# Altium schematic sheet Connectors.SchDoc(Connectors)
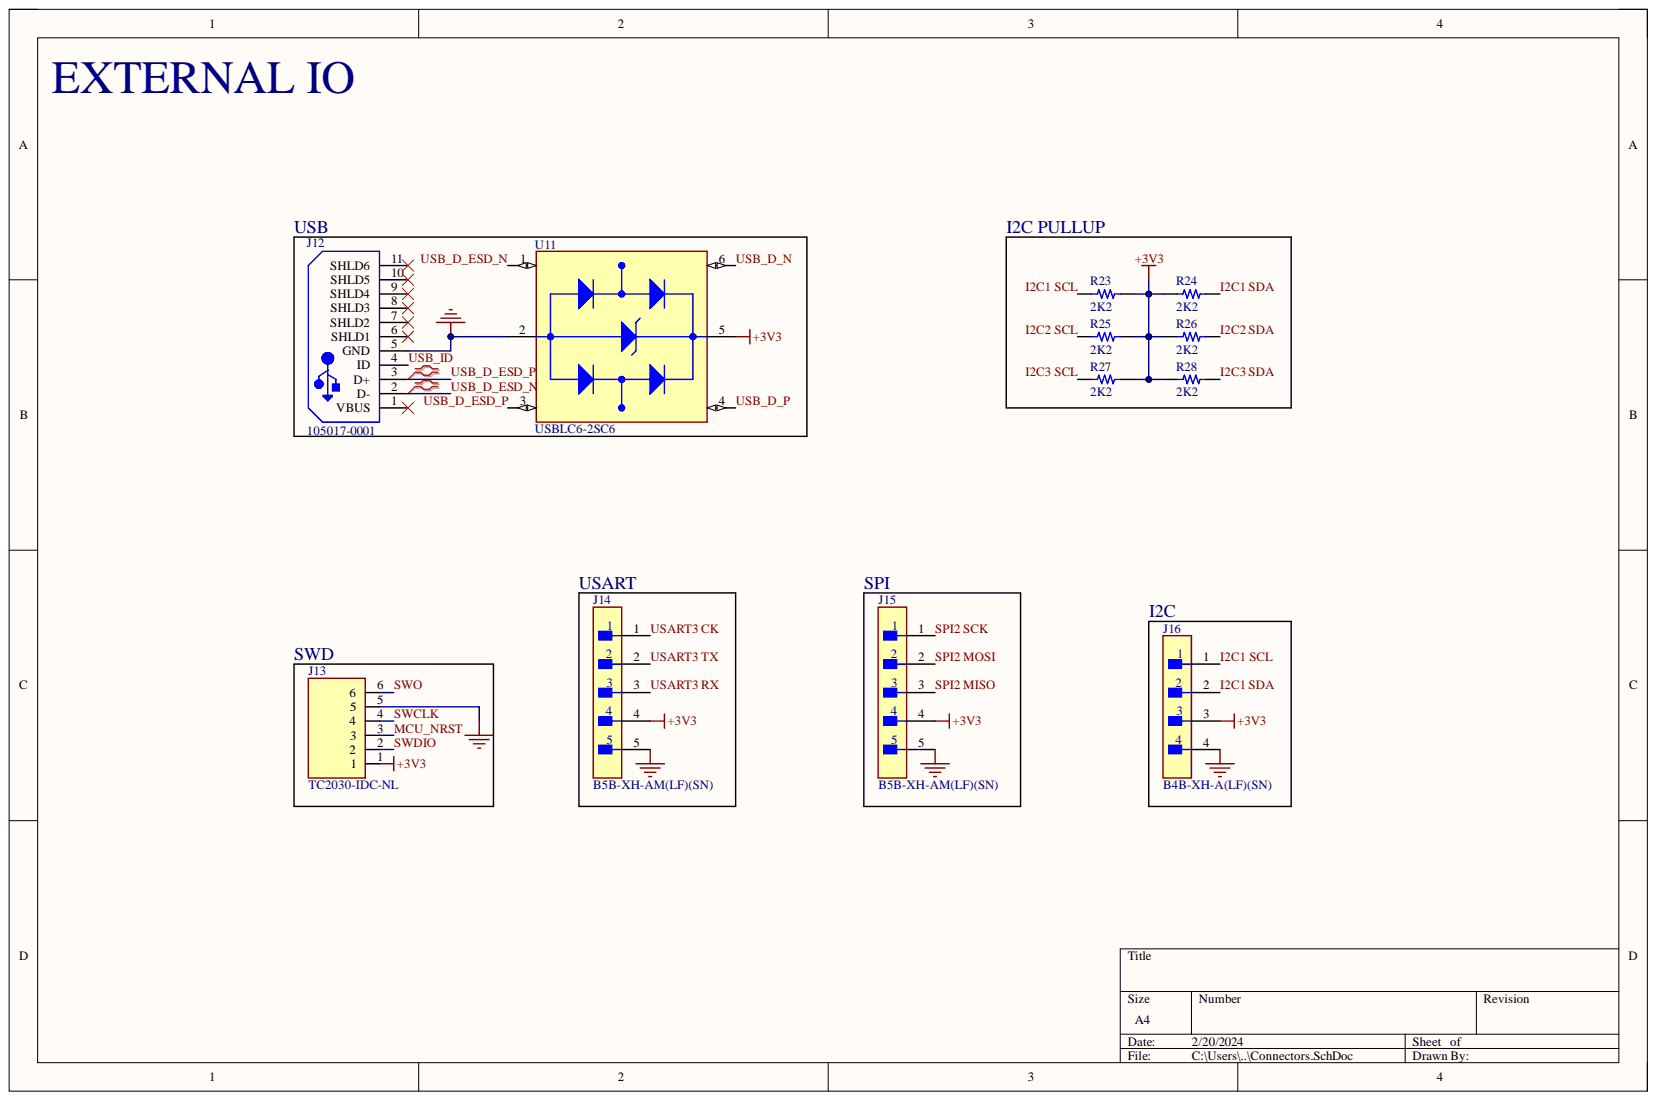
[diagram p0: Connectors.SchDoc]

## Parts
J12  105017-0001  [USB-MICRO-B_V]
J13  TC2030-IDC-NL  [TAG_TC2030-IDC-NL]
J14  B5B-XH-AM(LF)(SN)  [FP-B5B-XH-AM_LF_SN-MFG]
J15  B5B-XH-AM(LF)(SN)  [FP-B5B-XH-AM_LF_SN-MFG]
J16  B4B-XH-A(LF)(SN)  [FP-B4B-XH-A_LF_SN-MFG]
R23  2K2  [RES 0402_1005]
R24  2K2  [RES 0402_1005]
R25  2K2  [RES 0402_1005]
R26  2K2  [RES 0402_1005]
R27  2K2  [RES 0402_1005]
R28  2K2  [RES 0402_1005]
U11  USBLC6-2SC6  [SOT95P280X145-6N]

## Nets
+3V3: J13.1 J14.4 J15.4 J16.3 R23.1 R24.2 R25.1 R26.2 R27.1 R28.2 U11.5
GND: J12.5(GND) J13.5 J14.5 J15.5 J16.4 U11.2
I2C1 SCL: J16.1 R23.2
I2C1 SDA: J16.2 R24.1
I2C2 SCL: R25.2
I2C2 SDA: R26.1
I2C3 SCL: R27.2
I2C3 SDA: R28.1
MCU_NRST: J13.3
SPI2 MISO: J15.3
SPI2 MOSI: J15.2
SPI2 SCK: J15.1
SWCLK: J13.4
SWDIO: J13.2
SWO: J13.6
USART3 CK: J14.1
USART3 RX: J14.3
USART3 TX: J14.2
USB_D_ESD_N: J12.2(D-) U11.1
USB_D_ESD_P: J12.3(D+) U11.3
USB_D_N: U11.6
USB_D_P: U11.4
USB_ID: J12.4(ID)

Not connected: J12 1(VBUS) 6(SHLD1) 7(SHLD2) 8(SHLD3) 9(SHLD4) 10(SHLD5) 11(SHLD6)

## Library data of the parts
J12 = CMP-2000-05827-1: Micro-USB B Receptacle, Right Angle, Bottom Mount, Surface Mount, with Solder Tabs, -30 to 85 degC, 5-Pin USB, RoHS, Tape and Reel; Manufacturer: Molex; 3D Model: 105017-0001.stp; Catalog Drawings: 1050170001; Circuits: 5; Connector Type: USB-microB; Featured Product: Micro-USBConnectorsUSBConnectors; Mating Products: WM17145-ND-CABLEMICROUSBBTOSTDA1.0MWM17147-ND-CABLEMICROUSBBTOSTDA2.0MWM17146-ND-CABLEMICROUSBBTOSTDA1.5M; Online Catalog: Molex-MicroUSB; Other Names: WM1399DKR; Package Version: Rev. F, 12/2012; PCN Assembly/Origin: ResinSupplier21/Oct/2015; Voltage - Max: 30V; Category: Connectors,Interconnects; Component Kind: Standard; Datasheet URL: http://www.molex.com/elqNow/elqRedir.htm?ref=http://www.molex.com/webdocs/datasheets/pdf/en-us/1050170001_IO_CONNECTORS.pdf; Datasheet Version: 03/2016; Family: USB,DVI,HDMIConnectors; Features: Shielded,SolderRetention; Gender: Receptacle; Ingress Protection: -; Library Name: Altium Content Vault; Manufacturer URL: http://www.molex.com/; Mounting Technology: SurfaceMount; Mounting Type: BoardCutout,SurfaceMount,RightAngle; Number of Contacts: 5; Package Description: 5-Leads USB, Micro B, Body 7.5 x 5 mm, Pitch 0.65 mm; Package Reference: MICRO-B; Package URL: http://www.molex.com/pdm_docs/sd/1050170001_sd.pdf; Packaging: Digi-Reel®; RoHS Information: 1050170001CertofCompliance; Series: -; Specifications: -; Standard Package: 1
J13 = TC2030-IDC-NL: CABLE ADAPTER 6 POS; Availability: Unavailable; Component Kind: Standard; Library Name: TC2030-IDC-NL.IntLib; MP: TC2030-IDC-NL; Package: None; Price: None
J14 J15 = CMP-17439-000304-1: CONN HEADER VERT 5POS 2.5MM; Contact Resistance: 20mR; Mated Stacking Heights: 9.8mm; Max Current Rating: 3A; Max Voltage Rating (AC): 250V; Number of Positions: 5; Color: Green; Component Kind: Standard; Connector Type: Header; Contact Finish - Mating: TIN; Contact Material: Brass; Contact Plating: Copper; Contact Shape: Square; Contact Type: Male Pin; Depth: 5.75mm; Fastening Type: Detent; Flammability Rating: UL94 V-0; Housing Color: White; Housing Material: Polyamide; Insulation Color: Natural; Insulation Material: Nylon; Lead Free: Lead Free; Length: 14.9mm; Library Name: Altium Content Vault; Max Operating Temperature: 85°C; Min Operating Temperature: -25°C; Mount: Through Hole; Number of Rows: 1; Orientation: Straight; Packaging: Bulk; Pitch: 2.5mm; Series: XH; Termination: Solder; Voltage Rating: 250V
J16 = CMP-17439-000250-1: CONN HEADER VERT 4POS 2.5MM; Contact Resistance: 20mR; Current Rating: 3A; Gender: Male; Insulation Resistance: 1GR; Mated Stacking Heights: 9.8mm; Max Voltage Rating (AC): 250V; Number of Contacts: 4; Wire/Cable Gauge: 22AWG; Color: Natural; Component Kind: Standard; Connector Type: Header; Contact Finish - Mating: TIN; Contact Material: Brass; Contact Plating: Tin; Contact Shape: Square; Contact Type: Male Pin; Depth: 5.75mm; Fastening Type: Detent; Flammability Rating: UL94 V-0; Housing Color: White; Housing Material: Polyamide; Insulation Color: Natural; Insulation Material: Nylon; Lead Free: Lead Free; Lead Pitch: 2.5mm; Length: 12.4mm; Library Name: Altium Content Vault; Material: Polyamide; Max Current Rating: 3A; Max Operating Temperature: 85°C; Max Voltage Rating (DC): 250V; Min Operating Temperature: -25°C; Mount: Through Hole; Number of Positions: 4; Number of Rows: 1; Orientation: Straight; Package Quantity: 500; Packaging: Bulk; Pitch: 2.5mm; REACH SVHC: No SVHC; Series: XH; Termination: Solder; Voltage Rating: 250V; Voltage Rating (AC): 250V
R23-R28 = 5112: 0402 JUMPER ON TAPE AND REEL; Database Table Name: Resistors - Surface Mount - 0402; Component Kind: Standard; Library Name: Celestial Altium Library - altium_library.DbLib; Part Number: 5112
U11 = USBLC6-2SC6: 17V Clamp 5A (8/20Âµs) Ipp Tvs Diode Surface Mount SOT-23-6; MF: STMicroelectronics; Availability: In Stock; Check_prices: https://www.snapeda.com/parts/USBLC6-2SC6/STMicroelectronics/view-part/?ref=eda; Component Kind: Standard; Library Name: USBLC6-2SC6.IntLib; MP: USBLC6-2SC6; Package: SOT-23-6 STMicroelectronics; Price: None; Purchase-URL: https://pricing.snapeda.com/search/part/USBLC6-2SC6/?ref=eda; SnapEDA_Link: https://www.snapeda.com/parts/USBLC6-2SC6/STMicroelectronics/view-part/?ref=snap
